# Altium schematic sheet Top_Sheet.SchDoc
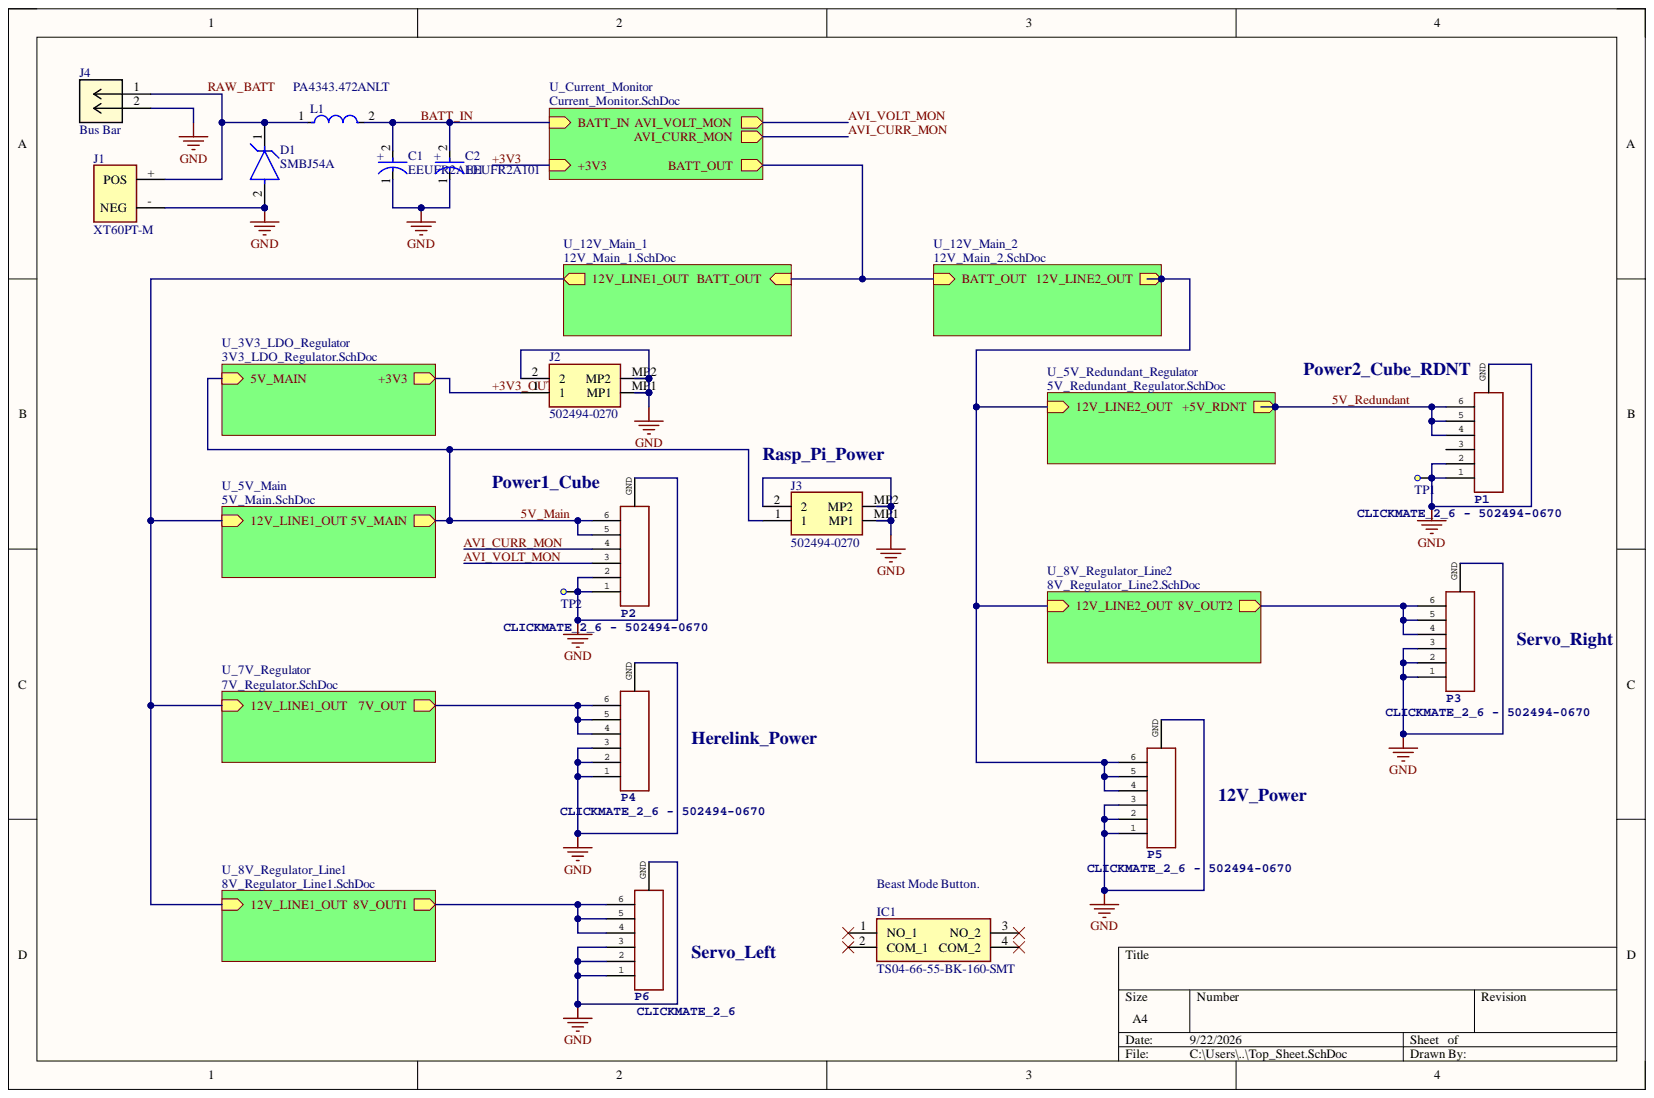
[diagram p0: Top_Sheet.SchDoc]

## Parts
C1  EEUFR2A101  [FP-RAD-TH-D_10_0_5-L_20_2-MFG]
C2  EEUFR2A101  [FP-RAD-TH-D_10_0_5-L_20_2-MFG]
D1  SMBJ54A  [FP-MKT-DO214AA-MFG]
IC1  TS04-66-55-BK-160-SMT  [TS046655BK160SMT]
J1  XT60PT-M  [XT60PT-M]
J2  502494-0270  [5024940270]
J3  502494-0270  [5024940270]
J4  Bus Bar  [Bus Bars]
L1  PA4343.472ANLT  [FP-PA4343_472ANLT-MFG]
P1  CLICKMATE_2_6 - 502494-0670  [CLICKMATE_H6_2MM]
P2  CLICKMATE_2_6 - 502494-0670  [CLICKMATE_H6_2MM]
P3  CLICKMATE_2_6 - 502494-0670  [CLICKMATE_H6_2MM]
P4  CLICKMATE_2_6 - 502494-0670  [CLICKMATE_H6_2MM]
P5  CLICKMATE_2_6 - 502494-0670  [CLICKMATE_H6_2MM]
P6  CLICKMATE_2_6  [CLICKMATE_H6_2MM]
TP1  Test point  [TestPoint]  (value not drawn)
TP2  Test point  [TestPoint]  (value not drawn)

## Nets
GND: C1.1 C2.1 D1.2 J1.- J2.2 J2.MP1 J2.MP2 J3.2 J3.MP1 J3.MP2 J4.2 P1.1 P1.2 P1.GND P2.1 P2.2 P2.GND P3.1 P3.2 P3.3 P3.GND P4.1 P4.2 P4.3 P4.GND P5.1 P5.2 P5.3 P5.GND P6.1 P6.2 P6.3 P6.GND TP1.TP? TP2.TP?
5V_Main: J3.1 P2.5 P2.6
5V_Redundant: P1.4 P1.5 P1.6
+3V3_OUT: J2.1
AVI_CURR_MON: P2.4
AVI_VOLT_MON: P2.3
BATT_IN: C1.2 C2.2 L1.2
RAW_BATT: D1.1 J1.+ J4.1 L1.1
NetIC1_1: IC1.1(NO_1)
NetIC1_2: IC1.2(COM_1)
NetIC1_3: IC1.3(NO_2)
NetIC1_4: IC1.4(COM_2)
NetP1_3: P1.3
NetP3_4: P3.4 P3.5 P3.6
NetP4_4: P4.4 P4.5 P4.6
NetP5_4: P5.4 P5.5 P5.6
NetP6_4: P6.4 P6.5 P6.6
12V_LINE1_OUT:
+3V3:
BATT_OUT:

## Library data of the parts
C1 C2 = CMP-05427-001096-1: Aluminum Electrolytic Capacitors (Radial Lead Type) 100uF ±20% 100V; Dielectric Material: Aluminium; Lead Diameter: 0.6mm; Lead Length: 17mm; Life (Hours): 10000Hour; Capacitance: 100uF; Component Kind: Standard; Diameter: 10mm; Height: 20mm; Lead Pitch: 5mm; Library Name: Altium Content Vault; Max Operating Temperature: 105°C; Min Operating Temperature: -40°C; REACH SVHC: No SVHC; RoHS Compliant: Yes; Series: FR; Termination: Radial; Tolerance: 20%; Voltage: 100V; Voltage Rating: 100V; Voltage Rating (DC): 100V
D1 = CMP-00278-10313491-1: SMBJ54A Diode TVS Single Uni-Dir 54V 600W 2-Pin DO-214AA T/R - Tape and Reel; Breakdown Voltage: 66.3V; Clamping Voltage: 87.1V; Direction: Unidirectional; ESD Protection: No; Leakage Current: 5µA; Max Surge Current: 6.9A; Min Breakdown Voltage: 60V; Number of Channels: 1; Test Current: 1mA; Case/Package: SMB; Component Kind: Standard; Composition: Zener; Contact Plating: Tin; Element Configuration: Single; Height: 2.45mm; Lead Free: Lead Free; Length: 4.75mm; Library Name: Altium Content Vault; Max Breakdown Voltage: 66.3V; Max Operating Temperature: 150°C; Max Power Dissipation: 600W; Max Reverse Leakage Current: 5µA; Min Operating Temperature: -55°C; Mount: Surface Mount; NexarPartId: 10313491; Number of Elements: 1; Number of Pins: 2; Number of Unidirectional Channels: 1; Operating Supply Voltage: 54V; Packaging: Tape & Reel; Peak Pulse Current: 6.9A; Peak Pulse Power: 600W; Polarity: Unidirectional; Power Dissipation: 600W; Power Line Protection: No; Power Rating: 600W; Radiation Hardening: No; REACH SVHC: No SVHC; Reverse Breakdown Voltage: 60V; Reverse Standoff Voltage: 54V; RoHS: Compliant; Termination: SMD/SMT; Voltage Rating (DC): 54V; Weight: 180mg; Width: 3.95mm
IC1 = TS04-66-55-BK-160-SMT: Integrated Circuit; Manufacturer_Name: Same Sky; Arrow Part Number: TS04-66-55-BK-160-SMT; Arrow Price/Stock: https://www.arrow.com/en/products/ts04-66-55-bk-160-smt/cui-devices?utm_currency=USD&region=nac; Component Kind: Standard; Datasheet Link: https://www.sameskydevices.com/product/resource/supplyframepdf/ts04.pdf; Height: 5.7mm; Library Name: SamacSys.SchLib; Manufacturer_Part_Number: TS04-66-55-BK-160-SMT; Mouser Part Number: 179-TS046655BK160SMT; Mouser Price/Stock: https://www.mouser.co.uk/ProductDetail/CUI-Devices/TS04-66-55-BK-160-SMT?qs=A6eO%252BMLsxmSf4UtMOd5Kug%3D%3D
J1 = XT60PT-M: Male SMT XT60 Connector; Component Kind: Standard; Library Name: Daughter_SchLib.SchLib
J2 J3 = 502494-0270: Connector; Manufacturer_Name: Molex; Arrow Part Number: 502494-0270; Arrow Price/Stock: https://www.arrow.com/en/products/5024940270/molex; Component Kind: Standard; Datasheet Link: https://componentsearchengine.com/Datasheets/1/502494-0270.pdf; Height: 6.85mm; Manufacturer_Part_Number: 502494-0270; Mouser Part Number: 538-502494-0270; Mouser Price/Stock: https://www.mouser.co.uk/ProductDetail/Molex/502494-0270?qs=sccQW%252BrT69bVmCdKb5dQ%2FA%3D%3D
J4 = Bus Bars: Component Kind: Standard; Library Name: PDB26_SchLib.SchLib
L1 = CMP-00567-88049020-1: PA4343.472ANLT Inductor Power Shielded/Molded Wirewound 4.7uH 20% 100KHz 20A 0.008Ohm DCR Automotive T/R; Inductance: 4.7µH; Component Kind: Standard; Library Name: Altium Content Vault; Lifecycle Status: Production; NexarPartId: 88049020; RoHS: Non-Compliant; Schedule B: 8504500000, 8504500000
P1-P6 = CLICKMATE_2_6: Connector, Header, 6Pos, 2.54MM, Vert, THT, Au; Component Kind: Standard; Supplier 1: Digi-Key; Supplier Part Number 1: WM2279CT-ND
  P1-P5: Library Name: Daughter_SchLib.SchLib
  P6: Library Name: PDB26_SchLib.SchLib
TP1 TP2 = TestPad: Component Kind: Standard; Library Name: Daughter_SchLib.SchLib; Value: Test point
